# Altium schematic sheet DaughterBoard.SchDoc
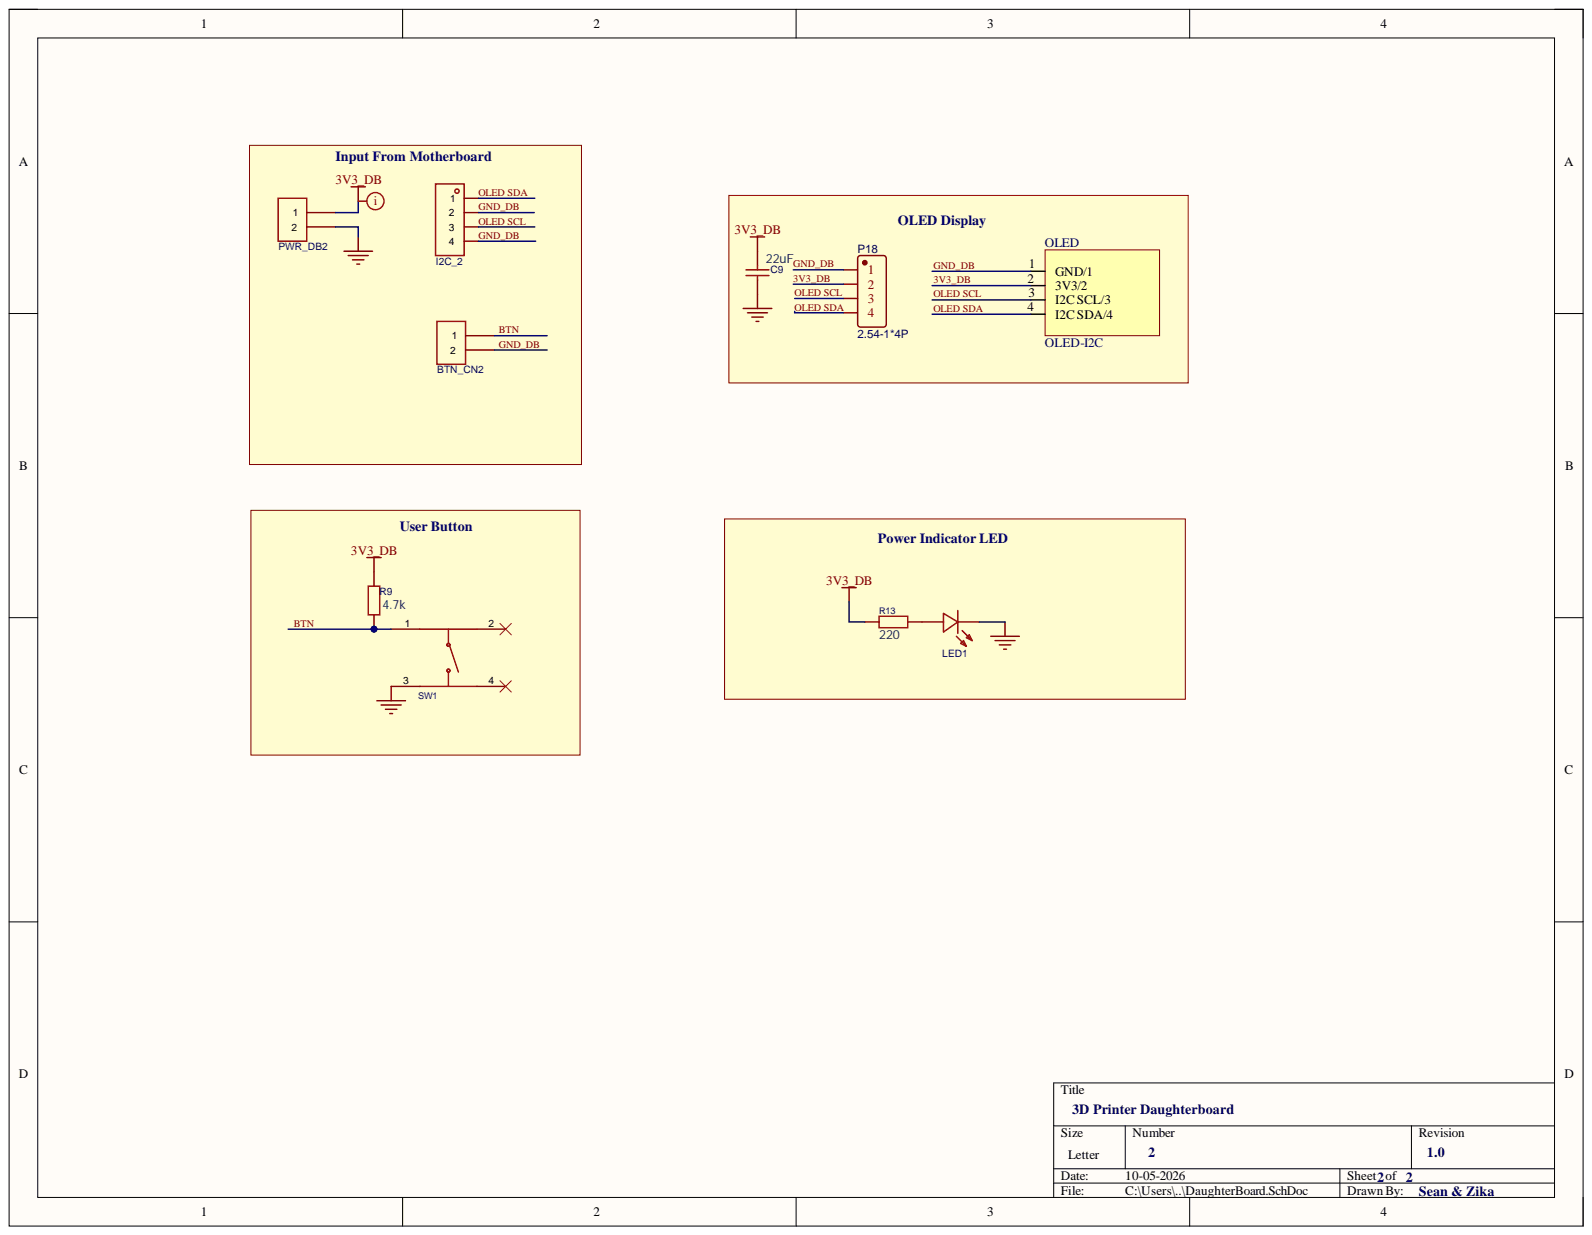
[diagram p0: DaughterBoard.SchDoc]

## Parts
BTN_CN2  wj127-5.0-02p-14-00a  [Screw-Terminal-2P]  (value not drawn)
C9  22uF  [Capacitor-22uF-0805]
I2C_2  wj127-5.0-04p-14-00a  [Screw-Terminal-4P]  (value not drawn)
LED1  ncd0805r1_c84256  [RED-LED-0805]  (value not drawn)
P18  2.54-1*4P  [Female-Header-4P]
PWR_DB2  wj127-5.0-02p-14-00a  [Screw-Terminal-2P]  (value not drawn)
R9  4.7k  [Resistor-4.7k-0805]
R13  220  [Resistor-220-0805]
SW1  ts6685h-250gf  [Tactile-SPST-Long]  (value not drawn)

## Nets
3V3_DB: C9.1 P18.2 PWR_DB2.1 R9.2 R13.1
BTN: BTN_CN2.1 R9.1 SW1.1
GND_DB: BTN_CN2.2 C9.2 I2C_2.2 I2C_2.4 LED1.1 P18.1 PWR_DB2.2 SW1.3
OLED SCL: I2C_2.3 P18.3
OLED SDA: I2C_2.1 P18.4
NetLED1_2: LED1.2 R13.2

Not connected: SW1 2 4

## Library data of the parts
BTN_CN2 PWR_DB2 = Screw-Terminal-2P: Number of Positions or Pins:1x2P Pitch:5mm Color:Green Mounting Type:Through Hole Number of Pins:2P Number of Rows:1 Number of PINs Per Row:2 Screw Specification:M2.5 Current Rating:15A Voltage Rating (Max):250V Wire Gauge - AWG:14~26 Wire Gauge - mm2:1.5; Manufacturer: KANGNEX(康奈克斯电气); AddInBom: yes; Current Rating: 15A; ModelId: 0c699dafc1fb4759bdee4490ad46ecba; ModelName: CONN-TH_2P-P5.00_CPC3703CTR; ModelTransform: 416.145,318.897,0,0,0,0,-11.811,5.315,-149.606; Number of PINs Per Row: 2; Number of Positions or Pins: 1x2P; Pitch: 5mm; Screw Specification: M2.5; Symbol: WJ127-5.0-2P; Voltage Rating (Max): 250V; Wire Gauge - AWG: 14~26; Wire Gauge - mm2: 1.5; Color: Green; Component Kind: Standard; ConvertToPcb: yes; Datasheet: https://item.szlcsc.com/datasheet/WJ127-5.0-02P-14-00A/4105.html; FootprintName: CONN-TH_2P-P5.00_CPC3703CTR; Height Above Board: 10.14mm; JLCPCB Part Class: Extended Part; LCSC Part Name: 1x2P 5mm 直插 排数:1 每排P数:2; Library Name: FinalProjectSchLib.SchLib; ManufacturerPart: WJ127-5.0-02P-14-00A; Mounting Type: Through Hole; Number of Pins: 2P; Number of Rows: 1; Operating Temperature: -40℃~+105℃; Supplier: LCSC; Supplier Footprint: 插件,P=5mm; SupplierPart: C3703
C9 = Capacitor-22uF-0805: Capacitance:22uF Tolerance:±20% Tolerance:±20% Voltage Rating:10V Temperature Coefficient:X5R; Manufacturer: SAMSUNG(三星); ManufacturerPart: CL21A226MPQNNNE; AddInBom: yes; ModelId: 8c88e81f49c6409b980720ec8953afb6; ModelName: C0805_L2.0-W1.3-H1.3; ModelTransform: 78.74,51.181,0,0,0,0,0,0.0005,0; Temperature Coefficient: X5R; Tolerance: ±20%; Voltage Rating: 10V; Component Kind: Standard; ConvertToPcb: yes; Datasheet: https://item.szlcsc.com/datasheet/CL21A226MPQNNNE/30029.html; FootprintName: C0805; JLCPCB Part Class: Extended Part; LCSC Part Name: 22uF ±20% 10V; Library Name: FinalProjectSchLib.SchLib; Supplier: LCSC; Supplier Footprint: 0805; SupplierPart: C29277; Symbol: CL21A226MPQNNNE; Value: 22uF
I2C_2 = Screw-Terminal-4P: Number of Positions or Pins:1x4P Pitch:5mm Color:Green Mounting Type:Through Hole Number of Pins:4P Number of Rows:1 Number of PINs Per Row:4 Screw Specification:M2.5 Current Rating:15A Voltage Rating (Max):250V Wire Gauge - AWG:14~26 Wire Gauge - mm2:1.5; Manufacturer: KANGNEX(康奈克斯电气); AddInBom: yes; Current Rating: 15A; ModelId: 30be887f740a4f6bacb9c64f3bb40a03; ModelName: CONN-TH_WJ5.00-LI-4P-127; ModelTransform: 807.0748,318.897,0,0,0,0,-9.843,7.874,-157.48; Number of PINs Per Row: 4; Number of Positions or Pins: 1x4P; Pitch: 5mm; Screw Specification: M2.5; Symbol: WJ127-5.0-4P; Voltage Rating (Max): 250V; Wire Gauge - AWG: 14~26; Wire Gauge - mm2: 1.5; Color: Green; Component Kind: Standard; ConvertToPcb: yes; Datasheet: https://item.szlcsc.com/datasheet/WJ127-5.0-04P-14-00A/225182.html; FootprintName: CONN-TH_4P-P5.00_WJ127-5.0-4P; Height Above Board: 10.14mm; JLCPCB Part Class: Extended Part; LCSC Part Name: 1x4P 5mm 直插 排数:1 每排P数:4; Library Name: FinalProjectSchLib.SchLib; ManufacturerPart: WJ127-5.0-04P-14-00A; Mounting Type: Through Hole; Number of Pins: 4P; Number of Rows: 1; Operating Temperature: -40℃~+105℃; Supplier: LCSC; Supplier Footprint: 插件,P=5mm; SupplierPart: C192779
LED1 = RED-LED-0805: Lamp Holder Type:- Illumination Color:Red Voltage - Forward(Vf):1.6V~2.6V Voltage - Forward(Vf):1.6V~2.6V Power(Watts):65mW Test Current:25mA Wavelength - Dominant:615nm~630nm Wavelength - Dominant:615nm~630nm Peak Wavelength:630nm Peak Wavelength:630nm D; Manufacturer: 国星光电; AddInBom: yes; Diode Configuration: Discrete Diode; Half wave width: 15nm; Illumination Color: Red; Luminous Intensity: 195mcd; ModelId: 23093642268545519703b953fc993978; ModelName: LED0805-RD; ModelTransform: 79.527,49.2125,0,0,0,0,0,0,0; Peak Wavelength: 630nm; Power(Watts): 65mW; Symbol: FC-2012HRK-620D; Test Current: 25mA; Viewing Angle: 130°; Color Temperature: -; Component Kind: Standard; ConvertToPcb: yes; Datasheet: https://item.szlcsc.com/datasheet/NCD0805R1/85425.html; Emitting Material: -; ESD Withstand Voltage: -; FootprintName: LED0805-RD; JLCPCB Part Class: Basic Part; Lamp Holder Type: -; LCSC Part Name: 片式发光二极管; Lens Color: -; Library Name: FinalProjectSchLib.SchLib; Lumens: -; ManufacturerPart: NCD0805R1; Mounting Style: Top-mount; Operating Temperature: -30℃~+85℃; Supplier: LCSC; Supplier Footprint: 0805; SupplierPart: C84256; Voltage - Forward(Vf): 1.6V~2.6V; Wavelength - Dominant: 615nm~630nm
P18 = Female-Header-4P: Manufacturer: BOOMELE(博穆精密); Manufacturer Part: 2.54-1*4P母; Contributor: LCSC; Component Kind: Standard; JLCPCB Part Class: Extended Part; Library Name: FinalProjectSchLib.SchLib; spicePre: H; spiceSymbolName: 2.54-1*4P母; Supplier: LCSC; SupplierPart: C2718488
R9 = Resistor-4.7k-0805: Type:Thick Film Resistors Resistance:4.7kΩ Tolerance:±1% Tolerance:±1% Power(Watts): Overload Voltage (Max): Temperature Coefficient:±100ppm/℃ Temperature Coefficient:±100ppm/℃ Operating Temperature Range:-55℃~+155℃ Operating Temperature Range:-55℃~+155℃; Manufacturer: UNI-ROYAL(厚声); ManufacturerPart: 0805W8F4701T5E; AddInBom: yes; ModelId: b83c7c5430874b8eb2319a65d9433429; ModelName: R0805_L2.0-W1.3-H0.6; ModelTransform: 78.74,51.181,0,0,0,0,0,-0.0005,0; Tolerance: ±1%; Value: 4.7kΩ; Component Kind: Standard; ConvertToPcb: yes; Datasheet: https://atta.szlcsc.com/upload/public/pdf/source/20200306/C422600_1E6D84923E4A46A82E41ADD87F860B5C.pdf; FootprintName: R0805; JLCPCB Part Class: Basic Part; LCSC Part Name: 4.7kΩ ±1% 125mW 厚膜电阻; Library Name: FinalProjectSchLib.SchLib; Operating Temperature Range: -55℃~+155℃; Supplier: LCSC; Supplier Footprint: 0805; SupplierPart: C17673; Symbol: 0805W8F4701T5E; Temperature Coefficient: ±100ppm/℃; Type: Thick Film Resistors
R13 = Resistor-220-0805: Type:Thick Film Resistor Resistance:220Ω Tolerance:±1% Tolerance:±1% Power(Watts):125mW Voltage-Supply(Max):150V Temperature Coefficient:±100ppm/℃ Temperature Coefficient:±100ppm/℃ Operating Temperature:-55℃~+155℃ Operating Temperature:-55℃~+155℃; Manufacturer: UNI-ROYAL(厚声); Manufacturer Part: 0805W8F2200T5E; AddInBom: yes; ModelId: b83c7c5430874b8eb2319a65d9433429; ModelName: R0805_L2.0-W1.3-H0.6; ModelTransform: 78.74,51.181,0,0,0,0,0,-0.0005,0; Power(Watts): 125mW; Tolerance: ±1%; Value: 220Ω; Voltage-Supply(Max): 150V; Component Kind: Standard; ConvertToPcb: yes; Datasheet: https://item.szlcsc.com/datasheet/0805W8F2200T5E/18245.html; FootprintName: R0805; JLCPCB Part Class: Basic Part; LCSC Part Name: 厚膜电阻 220Ω ±1%; Library Name: FinalProjectSchLib.SchLib; Operating Temperature: -55℃~+155℃; Supplier: LCSC; Supplier Footprint: 0805; SupplierPart: C17557; Symbol: 0805W8F2200T5E; Temperature Coefficient: ±100ppm/℃; Type: Thick Film Resistor
SW1 = Tactile-SPST-Long: Circuit:SPST Actuator Style:Round Button Operating Force:2.5N Mounting Type:Through Hole Length:6mm Width:6mm Switch Height:8.5mm Contact Current:50mA Voltage Rating:12V With Lamp:- Life:-; Manufacturer: YE; AddInBom: yes; Circuit: SPST; Contact Current: 50mA; ModelId: 1b2aa19db9484d8f9f922c3504714525; ModelName: SW-TH_4P-L6.0-W6.0-H5.0-LS6.5-P4.50; ModelTransform: 236.22,323.03,0,90,0,0,0,0,-137.795; Operating Force: 2.5N; Switch Height: 8.5mm; Symbol: C13828; Voltage Rating: 12V; Actuator Style: Round Button; Component Kind: Standard; ConvertToPcb: yes; Datasheet: https://item.szlcsc.com/datasheet/TS6685H-250gf/14483.html; FootprintName: SW-TH_4P-L6.0-W6.0-P4.50-LS6.5; JLCPCB Part Class: Extended Part; LCSC Part Name: 6*6*8.5mm 直插 轻触开关; Length: 6mm; Library Name: FinalProjectSchLib.SchLib; Life: -; Manufacturer Part: TS6685H-250gf; Mounting Type: Through Hole; Supplier: LCSC; Supplier Footprint: 插件; SupplierPart: C13828; Width: 6mm; With Lamp: -
